# Altium schematic sheet SparkaAway.SchDoc
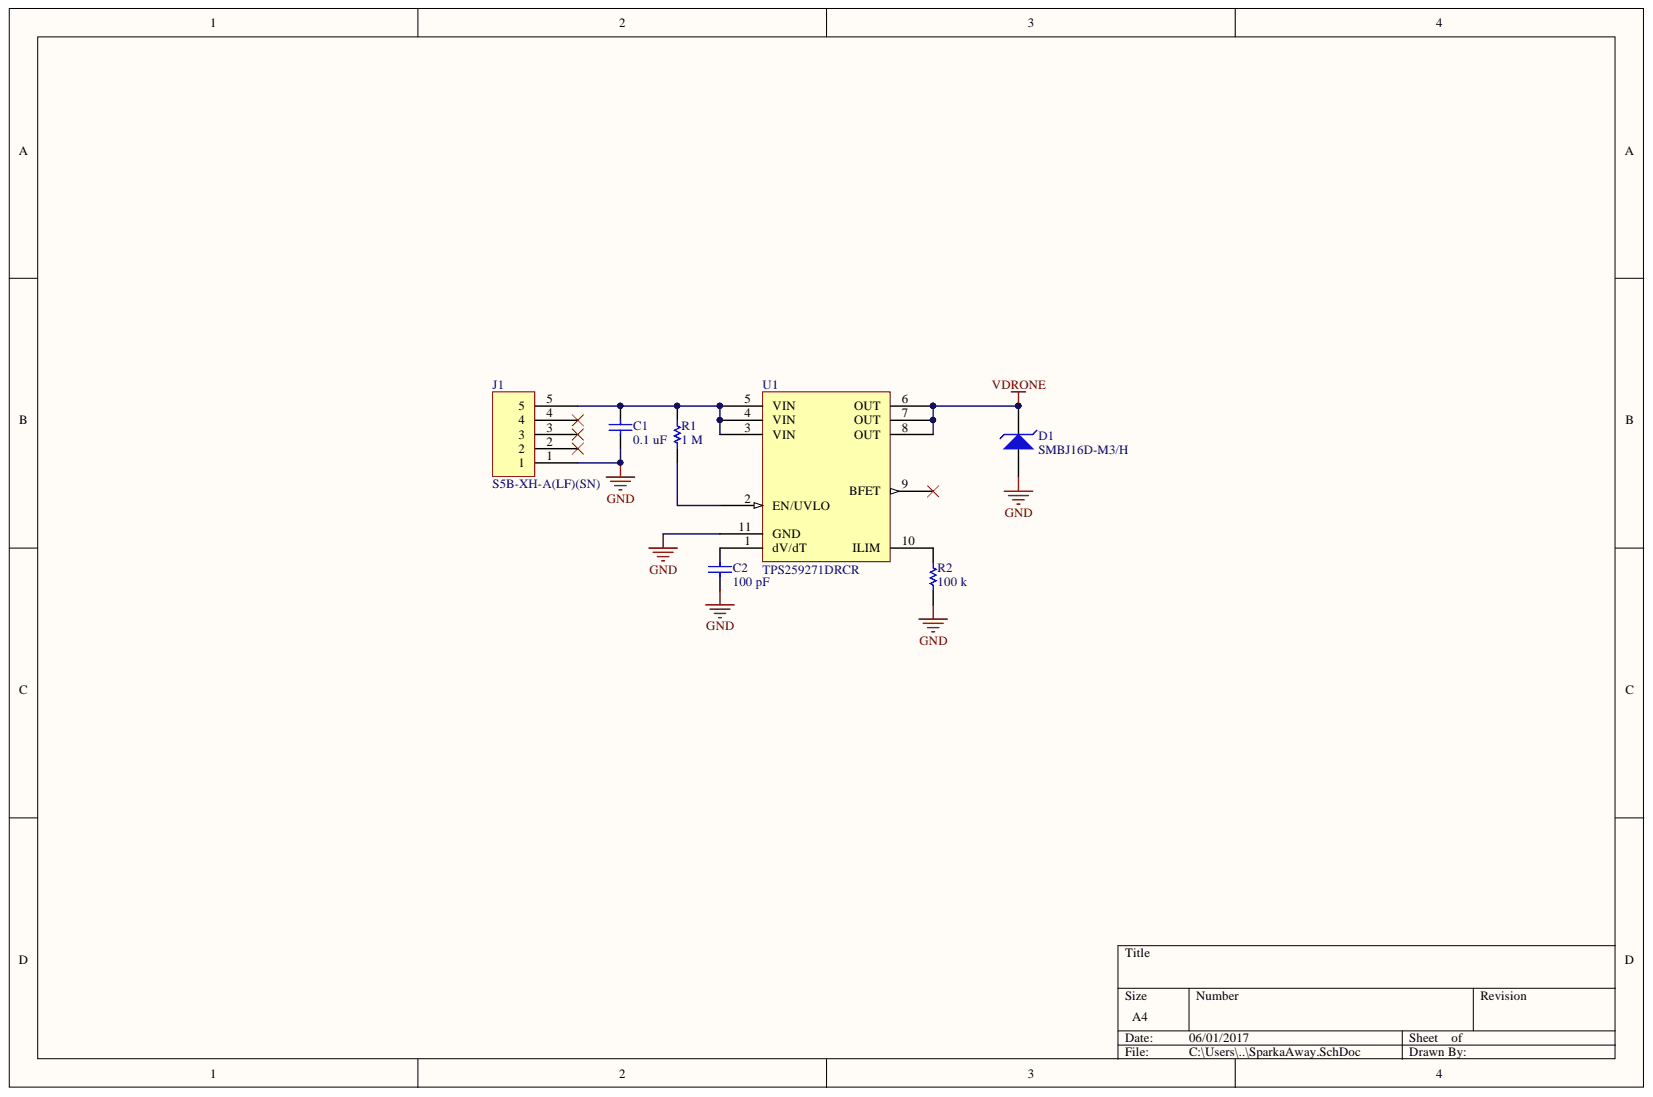
[diagram p0: SparkaAway.SchDoc]

## Parts
C1  0.1 uF  [CAPC1608X10L]
C2  100 pF  [CAPC1608X10L]
D1  SMBJ16D-M3/H  [DO-214AA SMB DIOM4336X24N]
J1  S5B-XH-A(LF)(SN)  [S5B-XH-A(LF)(SN)]
R1  1 M  [RESC1608X05N]
R2  100 k  [RESC1608X05N]
U1  TPS259271DRCR  [TI S-PVSON-N10 SON50P300X100_HS-11N]

## Nets
GND: C1.1 C2.1 D1.2 J1.1 R2.1 U1.11(GND)
VDRONE: D1.1 U1.6(OUT) U1.7(OUT) U1.8(OUT)
NetC1_2: C1.2 J1.5 R1.2 U1.3(VIN) U1.4(VIN) U1.5(VIN)
NetC2_2: C2.2 U1.1(dV/dT)
NetR1_1: R1.1 U1.2(EN/UVLO)
NetR2_2: R2.2 U1.10(ILIM)

Not connected: J1 2 3 4; U1 9(BFET)

## Library data of the parts
C1 = CL10B104MB8NNNC: CAP CER 0.1UF 50V X7R 0603; Manufacturer: Samsung Electro-Mechanics America, Inc.; Capacitance: 0.10µF; Tolerance: ±20%; Applications: General Purpose; Category: Capacitors; Component Kind: Standard; ComponentLink1Description: http://www.samsungsem.com/kr/support/product-search/mlcc/__icsFiles/afieldfile/2016/08/18/S_CL10B104MB8NNNC.pdf; ComponentLink1URL: http://www.samsungsem.com/kr/support/product-search/mlcc/__icsFiles/afieldfile/2016/08/18/S_CL10B104MB8NNNC.pdf; Features: -; Height - Seated (Max): -; Lead Spacing: -; Lead Style: -; Library Name: Componentes.SchLib; Manufacturer Part Number: CL10B104MB8NNNC; Mounting Type: Surface Mount, MLCC; Operating Temperature: -55°C ~ 125°C; Package / Case: 0603 (1608 Metric); Packaging: Cut Tape (CT); Part Status: Active; Pricing 1: 1=0.1, 10=0.023, 25=0.0168, 50=0.0126, 100=0.0104, 250=0.00868, 500=0.0074, 1000=0.00581 (EUR); Ratings: -; RoHS: RoHS Compliant; Size / Dimension: 0.063" L x 0.031" W (1.60mm x 0.80mm); Stock 1: 64597; Supplier 1: Digi-Key; Supplier Part Number 1: 1276-1941-1-ND; Temperature Coefficient: X7R; Thickness (Max): 0.035" (0.90mm); Voltage - Rated: 50V
C2 = CGA3E2C0G1H101J080AA: Multilayer Ceramic Capacitors MLCC - SMD/SMT 0603 100pF 50volts C0G 5% T=0.8mm; Brand: TDK; Dielectric: C0G (NP0); Type: Automotive Multilayer Ceramic Chip Capacitor; Voltage Rating DC: 50 VDC; Capacitance: 100 pF; Case Code - in: 0603; Case Code - mm: 1608; Category: Multilayer Ceramic Capacitors MLCC - SMD/SMT; Component Kind: Standard; ComponentLink1Description: http://www.mouser.com/ds/2/400/mlcc_automotive_general_en-269806.pdf; ComponentLink1URL: http://www.mouser.com/ds/2/400/mlcc_automotive_general_en-269806.pdf; Height: 0.8 mm; Length: 1.6 mm; Library Name: Componentes.SchLib; Manufacturer: TDK; Manufacturer Part Number: CGA3E2C0G1H101J080AA; Maximum Operating Temperature: + 125 C; Minimum Operating Temperature: - 55 C; Minimum Order Quantity: 1; Package/Case: 0603 (1608 metric); Packaging: Reel; Pricing 1: 1=0.091, 10=0.049, 100=0.016, 1000=0.013, 4000=0.010, 8000=0.008, 48000=0.007 (EUR); Product: Automotive MLCCs; Reeling: True; RoHS: RoHS Compliant; RoHS - Mouser: Y; Series: CGA; Standard Pack Qty: 4000; Stock 1: 27800; Supplier 1: Mouser; Supplier Part Number 1: 810-CGA3E2C0G1H101J; Termination Style: SMD/SMT; Tolerance: 5 %; Width: 0.8 mm
D1 = SMBJ16D-M3/H: TVS DIODE 16VWM 25.6VC DO214AA; Manufacturer: Vishay Semiconductor Diodes Division; Current - Peak Pulse (10/1000µs): 23.4A; Power - Peak Pulse: 600W; Power Line Protection: No; Type: Zener; Unidirectional Channels: 1; Voltage - Breakdown (Min): 18.1V; Voltage - Clamping (Max) @ Ipp: 25.6V; Voltage - Reverse Standoff (Typ): 16V; Applications: General Purpose; Bidirectional Channels: -; Capacitance @ Frequency: -; Category: Circuit Protection; Component Kind: Standard; ComponentLink1Description: http://www.vishay.com/docs/87606/87606.pdf; ComponentLink1URL: http://www.vishay.com/docs/87606/87606.pdf; Manufacturer Part Number: SMBJ16D-M3/H; Mounting Type: Surface Mount; Operating Temperature: -55°C ~ 150°C (TJ); Package / Case: DO-214AA, SMB; Packaging: Cut Tape (CT); Part Status: Active; Pricing 1: 1=0.34, 10=0.275, 25=0.2508, 100=0.1867, 250=0.16916 (EUR); RoHS: RoHS Compliant; Stock 1: 7542; Supplier 1: Digi-Key; Supplier Device Package: DO-214AA (SMBJ); Supplier Part Number 1: SMBJ16D-M3/HGICT-ND; Library Name: SparkAway.SchLib
J1 = S5B-XH-A(LF)(SN): CONN HEADER XH SIDE 5POS 2.5MM; Manufacturer: JST Sales America Inc.; Connector Type: Header, Shrouded; Number of Positions: 5; Number of Positions Loaded: All; Pitch: 0.098" (2.50mm); Category: Connectors, Interconnects; Color: Natural; Component Kind: Standard; ComponentLink1Description: http://www.jst-mfg.com/product/pdf/eng/eXH.pdf; ComponentLink1URL: http://www.jst-mfg.com/product/pdf/eng/eXH.pdf; Contact Finish: Tin; Contact Finish Thickness: -; Contact Mating Length: -; Contact Type: Male Pin; Fastening Type: Detent Lock; Features: -; Manufacturer Part Number: S5B-XH-A(LF)(SN); Mounting Type: Through Hole, Right Angle; Number of Rows: 1; Packaging: Bulk; Part Status: Active; Pricing 1: 1=0.29, 10=0.276, 100=0.1936, 500=0.16594, 1000=0.13827, 5000=0.11852, 10000=0.11062 (EUR); RoHS: RoHS Compliant; Row Spacing: -; Stock 1: 38810; Supplier 1: Digi-Key; Supplier Part Number 1: 455-2242-ND; Termination: Press-Fit; Library Name: SparkAway.SchLib
R1 = CRCW06031M00FKEA: Thick Film Resistors - SMD 1/10watt 1.0Mohms 1%; Brand: Vishay / Dale; Power Rating: 100 mW; Resistance: 1 MOhms; Temperature Coefficient: 100 PPM / K; Voltage Rating: 75 V; Case Code - in: 0603; Case Code - mm: 1608; Category: Thick Film Resistors - SMD; Component Kind: Standard; ComponentLink1Description: http://www.mouser.com/ds/2/427/dcrcwe3-109170.pdf; ComponentLink1URL: http://www.mouser.com/ds/2/427/dcrcwe3-109170.pdf; Height: 0.45 mm; Length: 1.55 mm; Library Name: Componentes.SchLib; Manufacturer: Vishay / Dale; Manufacturer Part Number: CRCW06031M00FKEA; Minimum Order Quantity: 1; Operating Temperature Range: - 55 C to + 155 C; Package/Case: 0603 (1608 metric); Packaging: Reel; Pricing 1: 1=0.091, 10=0.040, 100=0.024, 500=0.019, 1000=0.016, 5000=0.005, 50000=0.004 (EUR); Product: Thick Film Resistors SMD; Product Category: 0603 Thick Film Chip Resistors; Reeling: True; RoHS: RoHS Compliant By Exemption; RoHS - Mouser: E; Series: CRCW; Standard Pack Qty: 5000; Stock 1: 156208; Supplier 1: Mouser; Supplier Part Number 1: 71-CRCW0603-1.0M-E3; Termination Style: SMD/SMT; Tolerance: 1 %; Width: 0.85 mm
R2 = CRCW0603100KFKEA: Thick Film Resistors - SMD 1/10watt 100Kohms 1%; Brand: Vishay / Dale; Power Rating: 100 mW; Temperature Coefficient: 100 PPM / K; Voltage Rating: 75 V; Case Code - in: 0603; Case Code - mm: 1608; Category: Thick Film Resistors - SMD; Component Kind: Standard; ComponentLink1Description: http://www.mouser.com/ds/2/427/dcrcwe3-109170.pdf; ComponentLink1URL: http://www.mouser.com/ds/2/427/dcrcwe3-109170.pdf; Height: 0.45 mm; Length: 1.55 mm; Library Name: Componentes.SchLib; Manufacturer: Vishay / Dale; Manufacturer Part Number: CRCW0603100KFKEA; Minimum Order Quantity: 1; Operating Temperature Range: - 55 C to + 155 C; Package/Case: 0603 (1608 metric); Packaging: Reel; Pricing 1: 1=0.091, 10=0.040, 100=0.024, 500=0.019, 1000=0.016, 5000=0.005, 50000=0.004 (EUR); Product: Thick Film Resistors SMD; Product Category: 0603 Thick Film Chip Resistors; Reeling: True; Resistance: 100 kOhms; RoHS: RoHS Compliant By Exemption; RoHS - Mouser: E; Series: CRCW; Standard Pack Qty: 5000; Stock 1: 395641; Supplier 1: Mouser; Supplier Part Number 1: 71-CRCW0603-100K-E3; Termination Style: SMD/SMT; Tolerance: 1 %; Width: 0.85 mm
U1 = TPS259271DRCR: IC EFUSE 18V BFET DVR 5A 10VSON; Manufacturer: Texas Instruments; Current - Supply: 420µA; Feature Pins: EN; Internal Switch(s): Yes; Number of Channels: 1; Programmable Features: Current Limit, Slew Rate, UVLO; Voltage - Supply: 4.5 V ~ 18 V; Applications: General Purpose; Category: Integrated Circuits (ICs); Component Kind: Standard; ComponentLink1Description: http://www.ti.com/lit/ds/symlink/tps25927.pdf; ComponentLink1URL: http://www.ti.com/lit/ds/symlink/tps25927.pdf; Current - Output (Max): 5A; Features: Auto Retry, OVP, Thermal Limit; Manufacturer Part Number: TPS259271DRCR; Mounting Type: Surface Mount; Operating Temperature: -40°C ~ 85°C; Package / Case: 10-VFDFN Exposed Pad; Packaging: Cut Tape (CT); Part Status: Active; Pricing 1: 1=1.61, 10=1.444, 100=1.126, 500=0.9312, 1000=0.59388 (EUR); RoHS: RoHS Compliant; Stock 1: 466; Supplier 1: Digi-Key; Supplier Device Package: 10-VSON (3x3); Supplier Part Number 1: 296-44973-1-ND; Type: Hot Swap Controller; Library Name: SparkAway.SchLib
